# Altium schematic sheet TXmdl_1.SchDoc
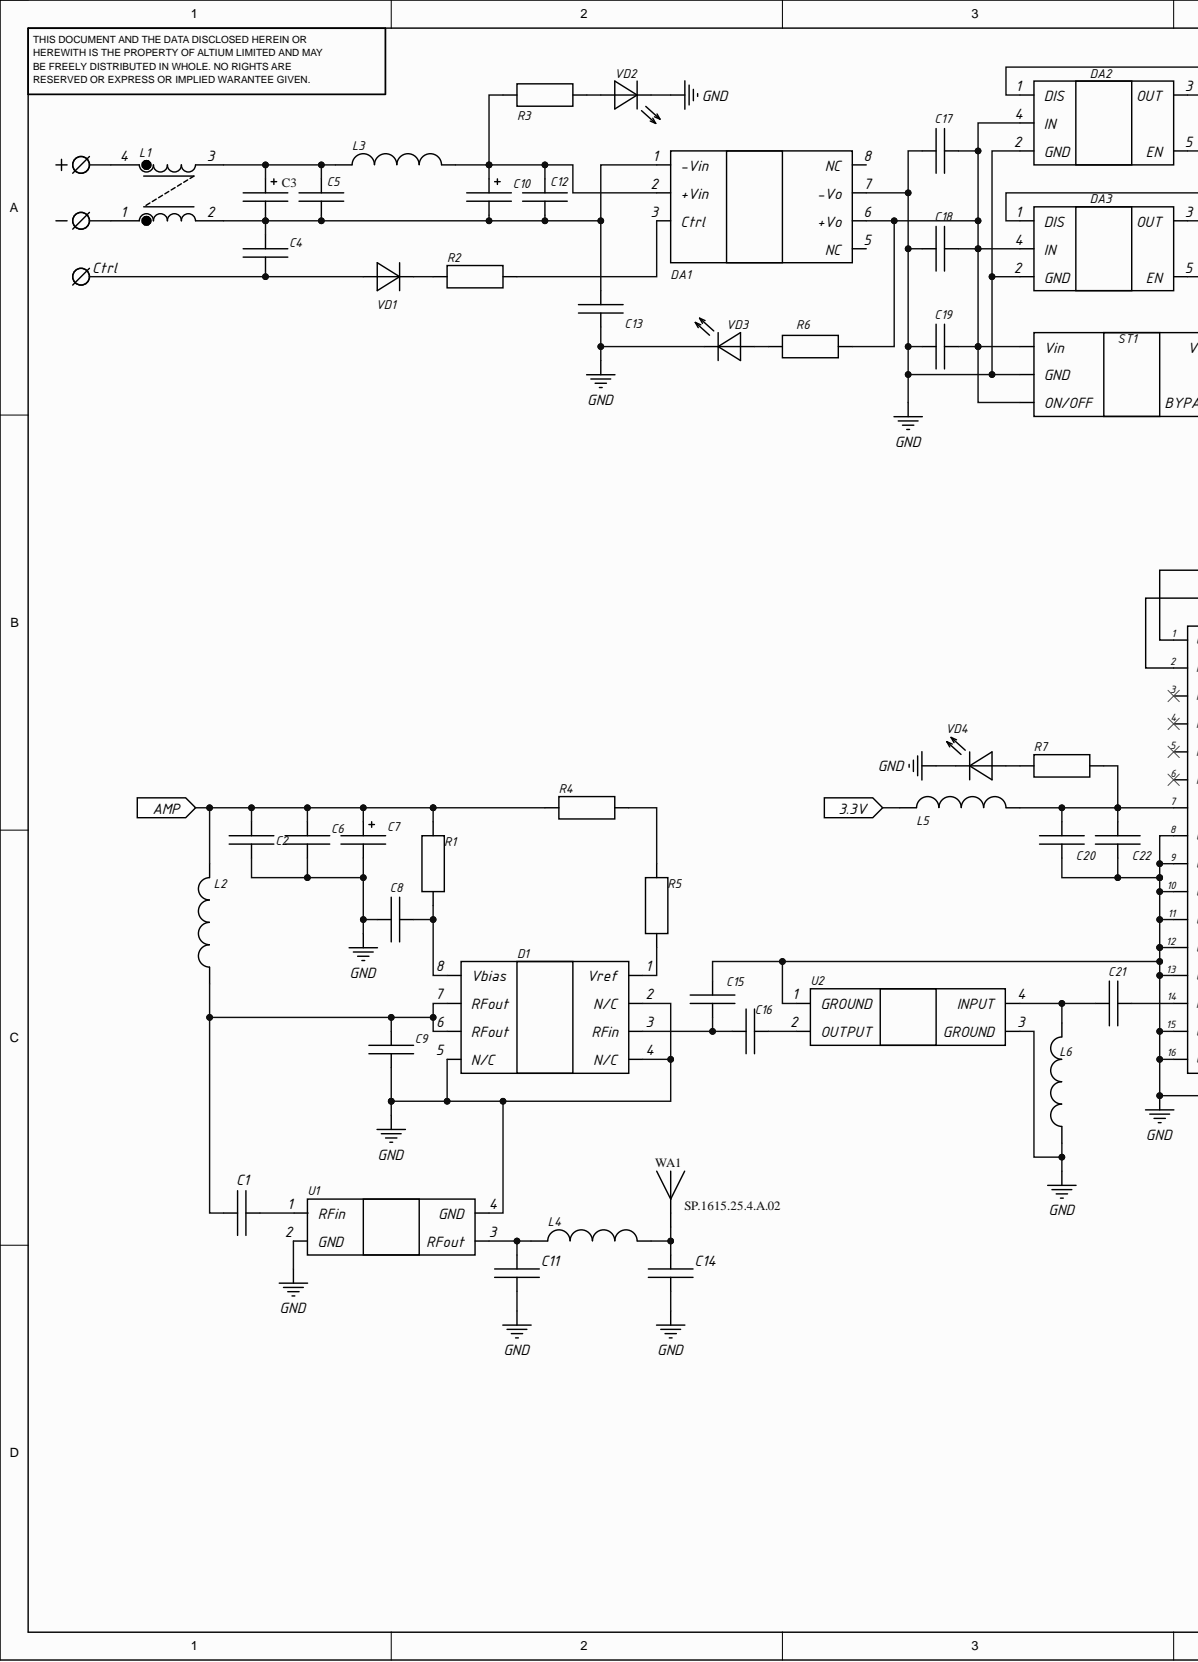
[diagram p0: TXmdl_1.SchDoc, left]
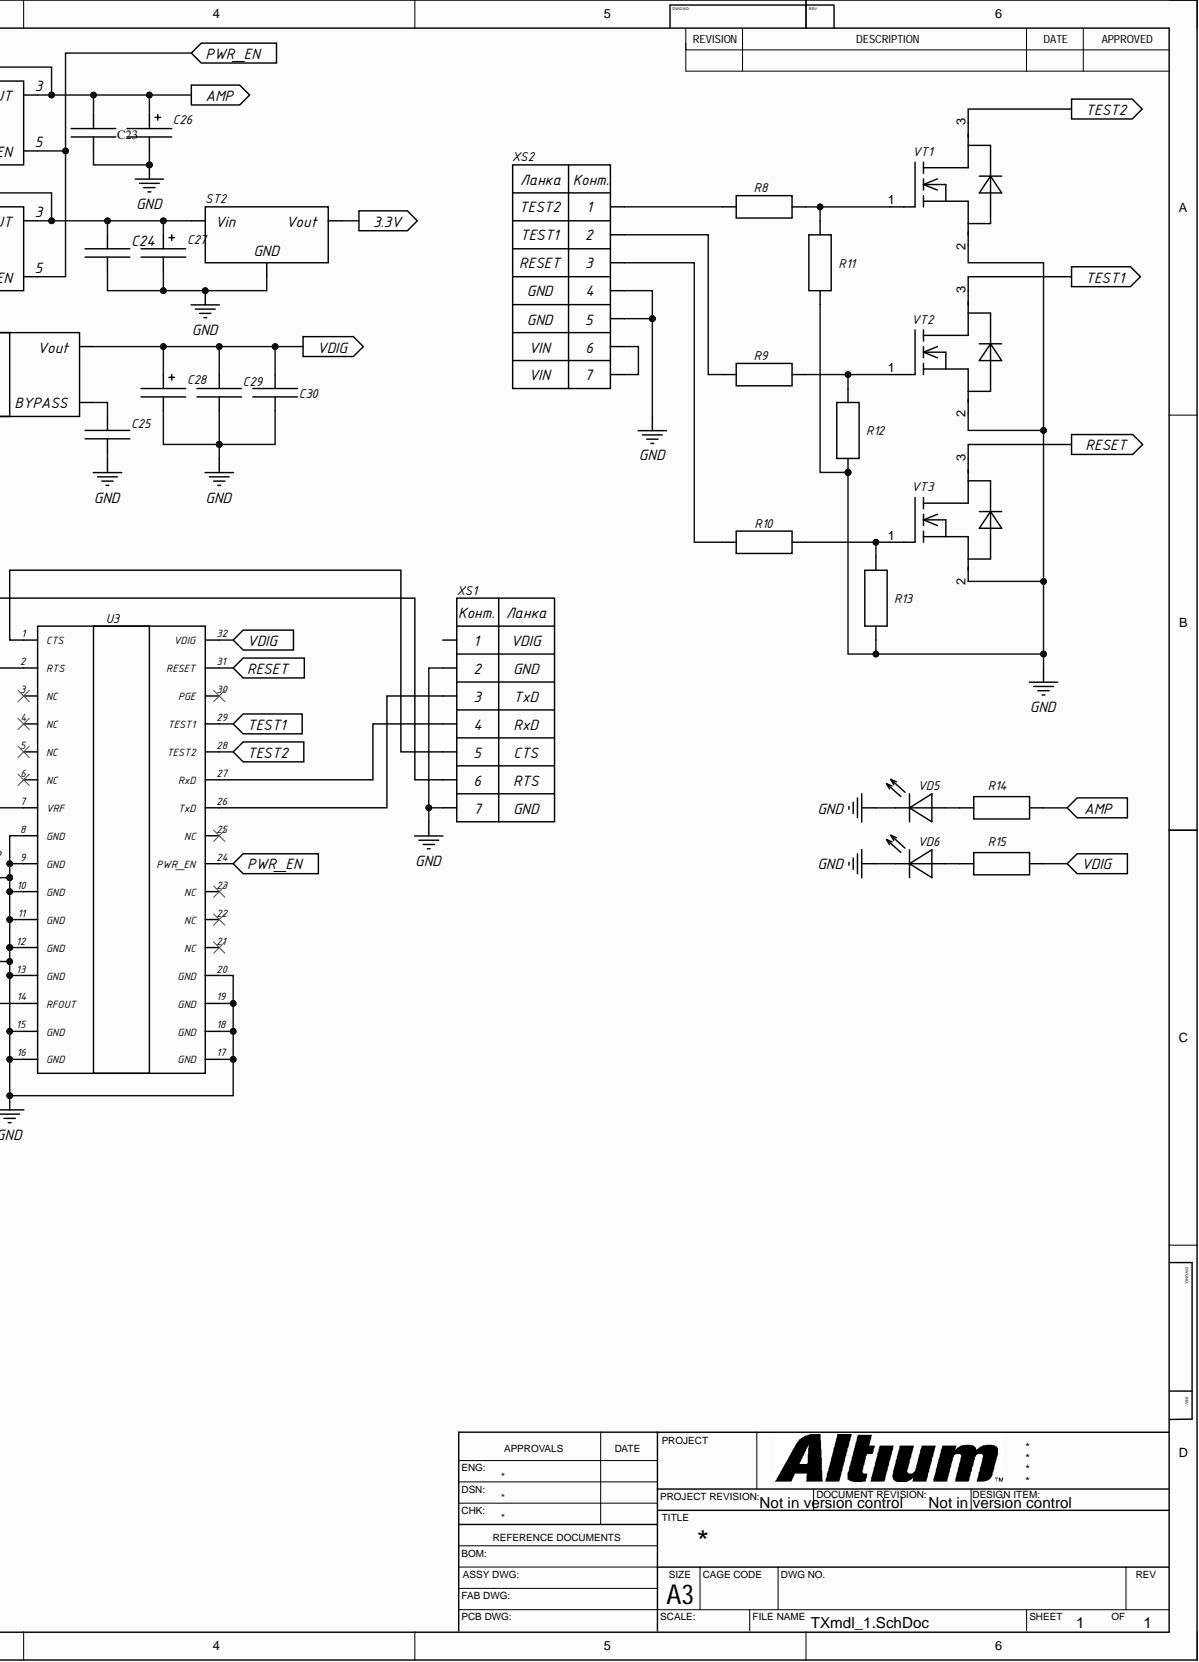
[diagram p1: TXmdl_1.SchDoc, right]

## Parts
C1  Capacitor  [CAP 0603]  (value not drawn)
C2  Capacitor  [CAP 0603]  (value not drawn)
C3  CAP_POL  [CP_Elec_SMD_10x10.5]  (value not drawn)
C4  Capacitor  [CAP 1210]  (value not drawn)
C5  Capacitor  [CAP 1206]  (value not drawn)
C6  Capacitor  [CAP 0603]  (value not drawn)
C7  CAP_POL  [TANTAL_C]  (value not drawn)
C8  Capacitor  [CAP 0603]  (value not drawn)
C9  Capacitor  [CAP 0603]  (value not drawn)
C10  CAP_POL  [CP_Elec_SMD_10x10.5]  (value not drawn)
C11  Capacitor  [CAP 0402]  (value not drawn)
C12  Capacitor  [CAP 1206]  (value not drawn)
C13  Capacitor  [CAP 1206]  (value not drawn)
C14  Capacitor  [CAP 0402]  (value not drawn)
C15  Capacitor  [CAP 0603]  (value not drawn)
C16  Capacitor  [CAP 0402]  (value not drawn)
C17  Capacitor  [CAP 0603]  (value not drawn)
C18  Capacitor  [CAP 0603]  (value not drawn)
C19  Capacitor  [CAP 0603]  (value not drawn)
C20  Capacitor  [CAP 0603]  (value not drawn)
C21  Capacitor  [CAP 0402]  (value not drawn)
C22  Capacitor  [CAP 0402]  (value not drawn)
C23  Capacitor  [CAP 0603]  (value not drawn)
C24  Capacitor  [CAP 0603]  (value not drawn)
C25  Capacitor  [CAP 0603]  (value not drawn)
C26  CAP_POL  [TANTAL_C]  (value not drawn)
C27  CAP_POL  [TANTAL_B]  (value not drawn)
C28  CAP_POL  [TANTAL_A]  (value not drawn)
C29  Capacitor  [CAP 0603]  (value not drawn)
C30  Capacitor  [CAP 0603]  (value not drawn)
D1  AH312-S8G  [SOIC-8]  (value not drawn)
DA1  URB4805S_10WR3  [URB(A)_S-10WR3]  (value not drawn)
DA2  AP2280  [SOT25]  (value not drawn)
DA3  AP2280  [SOT25]  (value not drawn)
L1  Toroidal_Chokes  [XFMR_HCTC-4-1.7]  (value not drawn)
L2  Inductance  [L 0603]  (value not drawn)
L3  Inductance  [SSB0503]  (value not drawn)
L4  Inductance  [L 0603]  (value not drawn)
L5  Ferrite_Bead  [L 0805]  (value not drawn)
L6  Inductance  [L 0603]  (value not drawn)
R1  Resistor  [RES 0603]  (value not drawn)
R2  Resistor  [RES 0603]  (value not drawn)
R3  Resistor  [RES 0603]  (value not drawn)
R4  Resistor  [RES 0603]  (value not drawn)
R5  Resistor  [RES 0603]  (value not drawn)
R6  Resistor  [RES 0603]  (value not drawn)
R7  Resistor  [RES 0603]  (value not drawn)
R8  Resistor  [RES 0603]  (value not drawn)
R9  Resistor  [RES 0603]  (value not drawn)
R10  Resistor  [RES 0603]  (value not drawn)
R11  Resistor  [RES 0603]  (value not drawn)
R12  Resistor  [RES 0603]  (value not drawn)
R13  Resistor  [RES 0603]  (value not drawn)
R14  Resistor  [RES 0603]  (value not drawn)
R15  Resistor  [RES 0603]  (value not drawn)
ST1  LP2985-33  [SOT-23_1.45]  (value not drawn)
ST2  LD1117-33  [DPAK_TO-252]  (value not drawn)
U1  BFCN-1860+  [FV1206-4]  (value not drawn)
U2  LAT-2+  [SOT143]  (value not drawn)
U3  STX3_Module  [STX3_TxSTL_32]  (value not drawn)
VD1  1N4148WS  [SOD323]  (value not drawn)
VD2  LED  [LED_SMD_0603]  (value not drawn)
VD3  LED  [LED_SMD_0603]  (value not drawn)
VD4  LED  [LED_SMD_0603]  (value not drawn)
VD5  LED  [LED_SMD_0603]  (value not drawn)
VD6  LED  [LED_SMD_0603]  (value not drawn)
VT1  2N7002  [SOT-23-3]  (value not drawn)
VT2  2N7002  [SOT-23-3]  (value not drawn)
VT3  2N7002  [SOT-23-3]  (value not drawn)
WA1  SP.1615.25.4.A.02  [A1615_25x25x4]
XS1  WPH-3002-1XX-2GXB-XR  [TSM-107-02-L-SV-A-P]  (value not drawn)
XS2  WPH-3002-1XX-2GXB-XR  [TSM-107-02-L-SV-A-P]  (value not drawn)

## Ports
3.3V, AMP, PWR_EN, RESET, TEST1, TEST2, VDIG

## Nets
GND: C2.2 C6.2 C7.2 C8.1 C9.2 C11.2 C13.2 C14.2 C15.1 C17.2 C18.2 C19.1 C20.2 C22.1 C23.2 C24.2 C25.1 C26.2 C27.2 C28.2 C29.1 C30.1 D1.2(N/C) D1.4(N/C) D1.5(N/C) DA1.7(-Vo) DA2.2(GND) DA3.2(GND) L6.1 R11.1 R12.1 R13.1 ST1.2(GND) ST2.1 U1.2(GND) U1.4(GND) U2.1(GROUND) U2.3(GROUND) U3.8(GND) U3.9(GND) U3.10(GND) U3.11(GND) U3.12(GND) U3.13(GND) U3.15(GND) U3.16(GND) U3.17(GND) U3.18(GND) U3.19(GND) U3.20(GND) VD2.2 VD3.2 VD4.2 VD5.2 VD6.2 VT1.2 VT2.2 VT3.2 XS1.2 XS1.7 XS2.4 XS2.5
3.3V: L5.1 ST2.2 ; port 3.3V
AMP: C2.1 C6.1 C7.1 C23.1 C26.1 DA2.1(DIS) DA2.3(OUT) L2.2 R1.2 R4.1 R14.1 ; port AMP
Ctrl: C4.2 VD1.2
PWR_EN: DA2.5(EN) DA3.5(EN) U3.24(PWR_EN) ; port PWR_EN
RESET: U3.31(RESET) VT3.3 ; port RESET
TEST1: U3.29(TEST1) VT2.3 ; port TEST1
TEST2: U3.28(TEST2) VT1.3 ; port TEST2
VDIG: C28.1 C29.2 C30.2 R15.1 ST1.5(Vout) U3.32(VDIG) ; port VDIG
NetC1_1: C1.1 C9.1 D1.6(RFout) D1.7(RFout) L2.1
NetC1_2: C1.2 U1.1(RFin)
NetC3_1: C3.1 C5.2 L1.3 L3.1
NetC3_2: C3.2 C4.1 C5.1 C10.2 C12.1 C13.1 DA1.1(-Vin) L1.2
NetC8_2: C8.2 D1.8(Vbias) R1.1
NetC10_1: C10.1 C12.2 DA1.2(+Vin) L3.2 R3.1
NetC11_1: C11.1 L4.1 U1.3(RFout)
NetC14_1: C14.1 L4.2 WA1.1
NetC15_2: C15.2 C16.1 D1.3(RFin)
NetC16_2: C16.2 U2.2(OUTPUT)
NetC17_1: C17.1 C18.1 C19.2 DA1.6(+Vo) DA2.4(IN) DA3.4(IN) R6.1 ST1.1(Vin) ST1.3(ON/OFF)
NetC20_1: C20.1 C22.2 L5.2 R7.1 U3.7(VRF)
NetC21_1: C21.1 L6.2 U2.4(INPUT)
NetC21_2: C21.2 U3.14(RFOUT)
NetC24_1: C24.1 C27.1 DA3.1(DIS) DA3.3(OUT) ST2.3
NetC25_2: C25.2 ST1.4(BYPASS)
NetD1_1: D1.1(Vref) R5.1
NetDA1_3: DA1.3(Ctrl) R2.2
NetR2_1: R2.1 VD1.1
NetR3_2: R3.2 VD2.1
NetR4_2: R4.2 R5.2
NetR6_2: R6.2 VD3.1
NetR7_2: R7.2 VD4.1
NetR8_1: R8.1 R11.2 VT1.1
NetR8_2: R8.2 XS2.1
NetR9_1: R9.1 R12.2 VT2.1
NetR9_2: R9.2 XS2.2
NetR10_1: R10.1 R13.2 VT3.1
NetR10_2: R10.2 XS2.3
NetR14_2: R14.2 VD5.1
NetR15_2: R15.2 VD6.1
NetU3_1: U3.1(CTS) XS1.5
NetU3_2: U3.2(RTS) XS1.6
NetU3_26: U3.26(TxD) XS1.3
NetU3_27: U3.27(RxD) XS1.4
NetXS2_6: XS2.6 XS2.7

Not connected: DA1 5(NC) 8(NC); L1 1 4; U3 3(NC) 4(NC) 5(NC) 6(NC) 21(NC) 22(NC) 23(NC) 25(NC) 30(PGE); XS1 1

## Library data of the parts
C1 C8 C15 C20 C25 C29 C30 = Capacitor CAP 0603 10 pF: Library Part: Capacitor.schlib; Database Table Name: CAPACITOR$; Units: pF; Value: 10; Component Kind: Standard; Footprint Part: PcbLibCAP.pcbLib; Library Name: DatabaseLib.DbLib; Part Number: Capacitor CAP 0603 10 pF
C2 C6 C9 = Capacitor CAP 0603 100 pF: Library Part: Capacitor.schlib; Database Table Name: CAPACITOR$; Units: pF; Value: 100; Component Kind: Standard; Footprint Part: PcbLibCAP.pcbLib; Library Name: DatabaseLib.DbLib; Part Number: Capacitor CAP 0603 100 pF
C3 C10 = CAP_POL CP_Elec_SMD_10x10.5 100 uF: Library Part: Capacitor.schlib; Database Table Name: CAPACITOR$; Rated Voltage: 50V; Tolerance: 0,1; Units: uF; Value: 100; Component Kind: Standard; Footprint Part: PcbLibCAP.pcbLib; Library Name: DatabaseLib.DbLib; Part Number: CAP_POL CP_Elec_SMD_10x10.5 100 uF
C4 = Capacitor CAP 1210 10 uF: Library Part: Capacitor.schlib; Database Table Name: CAPACITOR$; Rated Voltage: 50V; Tolerance: 0,1; Units: uF; Value: 10; Component Kind: Standard; Footprint Part: PcbLibCAP.pcbLib; Library Name: DatabaseLib.DbLib; Part Number: Capacitor CAP 1210 10 uF
C5 C12 C13 = Capacitor CAP 1206 10 uF: Library Part: Capacitor.schlib; Database Table Name: CAPACITOR$; Rated Voltage: 50V; Tolerance: 0,1; Units: uF; Value: 10; Component Kind: Standard; Footprint Part: PcbLibCAP.pcbLib; Library Name: DatabaseLib.DbLib; Part Number: Capacitor CAP 1206 10 uF
C7 = CAP_POL TANTAL_C 47 uF: Library Part: Capacitor.schlib; Database Table Name: CAPACITOR$; Units: uF; Value: 47; Component Kind: Standard; Footprint Part: PcbLibCAP.pcbLib; Library Name: DatabaseLib.DbLib; Part Number: CAP_POL TANTAL_C 47 uF
C11 C14 C16 C21 = Capacitor CAP 0402 1 pF: Library Part: Capacitor.schlib; Database Table Name: CAPACITOR$; Units: pF; Value: 1; Component Kind: Standard; Footprint Part: PcbLibCAP.pcbLib; Library Name: DatabaseLib.DbLib; Part Number: Capacitor CAP 0402 1 pF
C17-C19 = Capacitor CAP 0603 1 uF: Library Part: Capacitor.schlib; Database Table Name: CAPACITOR$; Units: uF; Value: 1; Component Kind: Standard; Footprint Part: PcbLibCAP.pcbLib; Library Name: DatabaseLib.DbLib; Part Number: Capacitor CAP 0603 1 uF
C22 = Capacitor CAP 0402 0.1 uF: Library Part: Capacitor.schlib; Database Table Name: CAPACITOR$; Units: uF; Value: 0.1; Component Kind: Standard; Footprint Part: PcbLibCAP.pcbLib; Library Name: DatabaseLib.DbLib; Part Number: Capacitor CAP 0402 0.1 uF
C23 C24 = Capacitor CAP 0603 0.1 uF: Library Part: Capacitor.schlib; Database Table Name: CAPACITOR$; Units: uF; Value: 0.1; Component Kind: Standard; Footprint Part: PcbLibCAP.pcbLib; Library Name: DatabaseLib.DbLib; Part Number: Capacitor CAP 0603 0.1 uF
C26 = CAP_POL TANTAL_C 10 uF: Library Part: Capacitor.schlib; Database Table Name: CAPACITOR$; Rated Voltage: 35V; Tolerance: 0,1; Units: uF; Value: 10; Component Kind: Standard; Footprint Part: PcbLibCAP.pcbLib; Library Name: DatabaseLib.DbLib; Part Number: CAP_POL TANTAL_C 10 uF
D1 = AH312-S8G SOIC-8: 400–2300 MHz  +33 dBm P1dB at 2140 MHz   +48 dBm Output IP3 at 2140 MHz  18 dB Gain at 900 MHz  +5 V Single Positive Supply; Library Part: Amplifier.schlib; Database Table Name: AMPLIFIER$; Component Kind: Standard; Footprint Part: PcbLibAMP.pcbLib; Library Name: DatabaseLib.DbLib; Part Number: AH312-S8G SOIC-8
DA1 = URB4805S_10WR3: Hi-Link  IN: 18-75VDC  OUT: 5VDC/2000mA  Power: 10 W; Library Part: DC_DC_Converter.schlib; Database Table Name: DC_DC_Converter$; Component Kind: Standard; Footprint Part: PcbLibDC_DC.pcbLib; Library Name: DatabaseLib.DbLib; Part Number: URB4805S_10WR3
DA2 DA3 = AP2280 SOT25: Library Part: LoadSwitch.schLib; Database Table Name: LOAD_SW$; Component Kind: Standard; Footprint Part: PcbLibLSW.pcbLib; Library Name: DatabaseLib.DbLib; Part Number: AP2280 SOT25
L2 L4 L6 = Inductance L 0603 39 nH: Library Part: Inductance.schlib; Database Table Name: INDUCTANCE$; Units: nH; Value: 39; Component Kind: Standard; Footprint Part: PcbLibL.pcbLib; Library Name: DatabaseLib.DbLib; Part Number: Inductance L 0603 39 nH
L3 = Inductance SSB0503 15 uH: Library Part: Inductance.schlib; Database Table Name: INDUCTANCE$; Units: uH; Value: 15; Component Kind: Standard; Footprint Part: PcbLibL.pcbLib; Library Name: DatabaseLib.DbLib; Part Number: Inductance SSB0503 15 uH
L5 = Ferrite_Bead L 0805 220 Om: Library Part: Inductance.schlib; Database Table Name: INDUCTANCE$; Units: Om; Value: 220; Component Kind: Standard; Footprint Part: PcbLibL.pcbLib; Library Name: DatabaseLib.DbLib; Part Number: Ferrite_Bead L 0805 220 Om
R1 R4 R5 = Resistor RES 0603 0 Om: Library Part: Resistor.schlib; Database Table Name: RESISTOR$; Units: Om; Value: 0; Component Kind: Standard; Footprint Part: PcbLibRES.pcbLib; Library Name: DatabaseLib.DbLib; Part Number: Resistor RES 0603 0 Om
R2 = Resistor RES 0603 100 Om: Library Part: Resistor.schlib; Database Table Name: RESISTOR$; Units: Om; Value: 100; Component Kind: Standard; Footprint Part: PcbLibRES.pcbLib; Library Name: DatabaseLib.DbLib; Part Number: Resistor RES 0603 100 Om
R3 R6 R7 R14 R15 = Resistor RES 0603 4.7 kOm: Library Part: Resistor.schlib; Database Table Name: RESISTOR$; Units: kOm; Value: 4.7; Component Kind: Standard; Footprint Part: PcbLibRES.pcbLib; Library Name: DatabaseLib.DbLib; Part Number: Resistor RES 0603 4.7 kOm
R8-R13 = Resistor RES 0603 1 Om: Library Part: Resistor.schlib; Database Table Name: RESISTOR$; Units: Om; Value: 1; Component Kind: Standard; Footprint Part: PcbLibRES.pcbLib; Library Name: DatabaseLib.DbLib; Part Number: Resistor RES 0603 1 Om
ST1 = LP2985-33 SOT-23_1.45: Library Part: LDO.schlib; Database Table Name: LDO_Converters$; Component Kind: Standard; Footprint Part: PcbLibLDO.pcbLib; Library Name: DatabaseLib.DbLib; Part Number: LP2985-33 SOT-23_1.45
ST2 = LD1117-33 DPAK_TO-252: Library Part: LDO.schlib; Database Table Name: LDO_Converters$; Component Kind: Standard; Footprint Part: PcbLibLDO.pcbLib; Library Name: DatabaseLib.DbLib; Part Number: LD1117-33 DPAK_TO-252
U1 = BFCN-1860+ FV1206-4: Bandpass Filter  1580 to 2200 MHz; Library Part: Filter.schlib; Database Table Name: FILTER$; Component Kind: Standard; Footprint Part: PcbLibFILTR.pcbLib; Library Name: DatabaseLib.DbLib; Part Number: BFCN-1860+ FV1206-4
U2 = LAT-2+ SOT143: wideband, DC to 2500 MHz; Library Part: RF_Attenuator.schlib; Database Table Name: RF_ATTENUATOR$; Component Kind: Standard; Footprint Part: PcbLibRFATTN.pcbLib; Library Name: DatabaseLib.DbLib; Part Number: LAT-2+ SOT143
U3 = STX3_Module STX3_TxSTL_32: STX3 satellite transmitter; Library Part: TRANSMITTER.schlib; Database Table Name: TRANSMITTER$; Component Kind: Standard; Footprint Part: PcbLibTxTER.pcbLib; Library Name: DatabaseLib.DbLib; Part Number: STX3_Module STX3_TxSTL_32
VD1 = 1N4148WS SOD323: High Speed Switching Diode  Features  - VR 75V  - IFAV 150mA; Library Part: Diode.schlib; Database Table Name: DIODE$; Component Kind: Standard; Footprint Part: PcbLibDIODE.pcbLib; Library Name: DatabaseLib.DbLib; Part Number: 1N4148WS SOD323
VD2-VD6 = LED_RGB LED_SMD_0603: Library Part: LED.schlib; Database Table Name: LED$; Component Kind: Standard; Footprint Part: PcbLibLED.pcbLib; Library Name: DatabaseLib.DbLib; Part Number: LED_RGB LED_SMD_0603
VT1-VT3 = 2N7002 SOT-23-3: Library Part: MOSFET.schlib; Database Table Name: MOSFET$; Component Kind: Standard; Footprint Part: PcbLibMOSFET.pcbLib; Library Name: DatabaseLib.DbLib; Part Number: 2N7002 SOT-23-3
WA1 = SP.1615.25.4.A.02 A1615_25x25x4: 25*25*4mm  Globalstar 25mm 1615Mhz; Library Part: Antenna.schlib; Database Table Name: ANTENNA$; Component Kind: Standard; Footprint Part: PcbLibANTNN.pcbLib; Library Name: DatabaseLib.DbLib; Part Number: SP.1615.25.4.A.02 A1615_25x25x4
C27 C28 = CAP_POL: Component Kind: Standard; Library Name: DatabaseLib.DbLib
L1 = Toroidal_Chokes: Component Kind: Standard; Library Name: DatabaseLib.DbLib
XS1 XS2 = WPH-3002-1XX-2GXB-XR: Component Kind: Standard; Library Name: DatabaseLib.DbLib
